# Altium schematic sheet [14] - POWER I.SchDoc("[14] - POWER I")
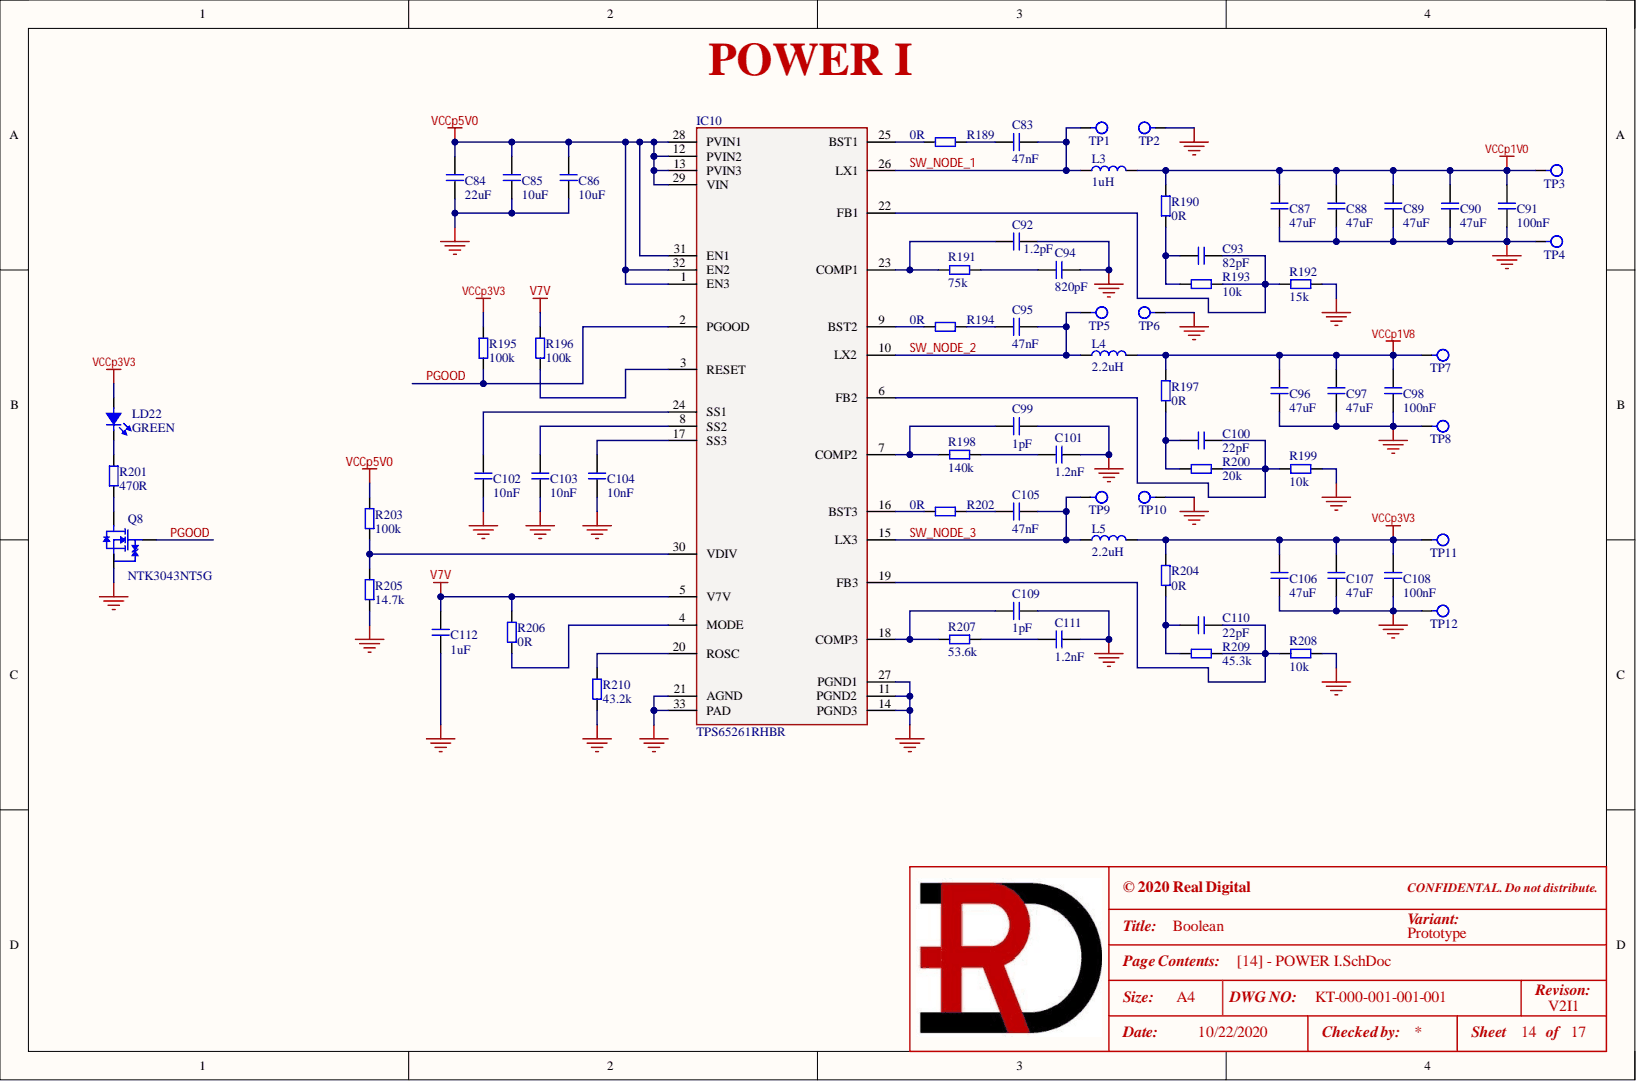
[diagram p0: [14] - POWER I.SchDoc]

## Parts
C83  47nF  [C0402]
C84  22uF  [C1210]
C85  10uF  [C1206]
C86  10uF  [C1206]
C87  47uF  [C1210]
C88  47uF  [C1210]
C89  47uF  [C1210]
C90  47uF  [C1210]
C91  100nF  [C0402]
C92  1.2pF  [C0402]
C93  82pF  [C0402]
C94  820pF  [C0402]
C95  47nF  [C0402]
C96  47uF  [C1210]
C97  47uF  [C1210]
C98  100nF  [C0402]
C99  1pF  [C0402]
C100  22pF  [C0402]
C101  1.2nF  [C0402]
C102  10nF  [C0402]
C103  10nF  [C0402]
C104  10nF  [C0402]
C105  47nF  [C0402]
C106  47uF  [C1210]
C107  47uF  [C1210]
C108  100nF  [C0402]
C109  1pF  [C0402]
C110  22pF  [C0402]
C111  1.2nF  [C0402]
C112  1uF  [C0603]
IC10  TPS65261RHBR  [VQFN-32_TI_RHB0032E]
L3  1uH  [BMRx00060630]
L4  2.2uH  [BMRx00060630]
L5  2.2uH  [BMRx00060630]
LD22  GREEN  [LD0603_Green]
Q8  NTK3043NT5G  [SOT723_ONSEMI]
R189  0R  [R0402]
R190  0R  [R0402]
R191  75k  [R0402]
R192  15k  [R0402]
R193  10k  [R0402]
R194  0R  [R0402]
R195  100k  [R0402]
R196  100k  [R0402]
R197  0R  [R0402]
R198  140k  [R0402]
R199  10k  [R0402]
R200  20k  [R0402]
R201  470R  [R0402]
R202  0R  [R0402]
R203  100k  [R0402]
R204  0R  [R0402]
R205  14.7k  [R0402]
R206  0R  [R0402]
R207  53.6k  [R0402]
R208  10k  [R0402]
R209  45.3k  [R0402]
R210  43.2k  [R0402]
TP1  1mm  [TP_1MM]  (value not drawn)  (no BOM)
TP2  1mm  [TP_1MM]  (value not drawn)  (no BOM)
TP3  1.5mm  [TP_1.5MM]  (value not drawn)  (no BOM)
TP4  1.5mm  [TP_1.5MM]  (value not drawn)  (no BOM)
TP5  1mm  [TP_1MM]  (value not drawn)  (no BOM)
TP6  1mm  [TP_1MM]  (value not drawn)  (no BOM)
TP7  1.5mm  [TP_1.5MM]  (value not drawn)  (no BOM)
TP8  1.5mm  [TP_1.5MM]  (value not drawn)  (no BOM)
TP9  1mm  [TP_1MM]  (value not drawn)  (no BOM)
TP10  1mm  [TP_1MM]  (value not drawn)  (no BOM)
TP11  1.5mm  [TP_1.5MM]  (value not drawn)  (no BOM)
TP12  1.5mm  [TP_1.5MM]  (value not drawn)  (no BOM)

## Nets
GND: C84.1 C85.1 C86.1 C87.1 C88.1 C89.1 C90.1 C91.1 C92.2 C94.2 C96.1 C97.1 C98.1 C99.2 C101.2 C102.1 C103.1 C104.1 C106.1 C107.1 C108.1 C109.2 C111.2 C112.1 IC10.11(PGND2) IC10.14(PGND3) IC10.21(AGND) IC10.27(PGND1) IC10.33(PAD) Q8.2 R192.1 R199.1 R205.1 R208.1 R210.1 TP2.1 TP4.1 TP6.1 TP8.1 TP10.1 TP12.1
V7V: C112.2 IC10.5(V7V) R196.2 R206.2
VCCp1V0: C87.2 C88.2 C89.2 C90.2 C91.2 L3.2 R190.2 TP3.1
VCCp1V8: C96.2 C97.2 C98.2 L4.2 R197.1 TP7.1
VCCp3V3: C106.2 C107.2 C108.2 L5.2 LD22.A R195.2 R204.1 TP11.1
VCCp5V0: C84.2 C85.2 C86.2 IC10.1(EN3) IC10.12(PVIN2) IC10.13(PVIN3) IC10.28(PVIN1) IC10.29(VIN) IC10.31(EN1) IC10.32(EN2) R203.2
PGOOD: IC10.2(PGOOD) Q8.1 R195.1
SW_NODE_1: C83.2 IC10.26(LX1) L3.1 TP1.1
SW_NODE_2: C95.2 IC10.10(LX2) L4.1 TP5.1
SW_NODE_3: C105.2 IC10.15(LX3) L5.1 TP9.1
NetC83_1: C83.1 R189.1
NetC92_1: C92.1 IC10.23(COMP1) R191.1
NetC93_1: C93.1 IC10.22(FB1) R192.2 R193.1
NetC93_2: C93.2 R190.1 R193.2
NetC94_1: C94.1 R191.2
NetC95_1: C95.1 R194.1
NetC99_1: C99.1 IC10.7(COMP2) R198.1
NetC100_1: C100.1 IC10.6(FB2) R199.2 R200.1
NetC100_2: C100.2 R197.2 R200.2
NetC101_1: C101.1 R198.2
NetC102_2: C102.2 IC10.24(SS1)
NetC103_2: C103.2 IC10.8(SS2)
NetC104_2: C104.2 IC10.17(SS3)
NetC105_1: C105.1 R202.1
NetC109_1: C109.1 IC10.18(COMP3) R207.1
NetC110_1: C110.1 IC10.19(FB3) R208.2 R209.1
NetC110_2: C110.2 R204.2 R209.2
NetC111_1: C111.1 R207.2
NetIC10_3: IC10.3(RESET) R196.1
NetIC10_4: IC10.4(MODE) R206.1
NetIC10_9: IC10.9(BST2) R194.2
NetIC10_16: IC10.16(BST3) R202.2
NetIC10_20: IC10.20(ROSC) R210.2
NetIC10_25: IC10.25(BST1) R189.2
NetIC10_30: IC10.30(VDIV) R203.1 R205.2
NetLD22_K: LD22.K R201.2
NetQ8_3: Q8.3 R201.1

## Library data of the parts
C83 C95 C105 = GRM155R71E473KA88J: CAP CER 0.047uF 25V X7R 0402; Manufacturer 1: Murata Electronics; .Checked_PCB: AC; .Creator: RV; C P/N: 111-0286; LoadStatus: Load; Tolerance: 10%; Category: Capacitors; Component Kind: Standard; Library Name: KT-RealDigital.IntLib; Manufacturer 1 Part Number: GRM155R71E473KA88J; Temperature Coefficient: X7R; Voltage - Rated: 25V; .Checked_SCH: AC
C84 = GCM32ER71C226ME19K: CAP CER 22uF 16V X7R 1210; Manufacturer 1: Murata Electronics; .Checked_PCB: AC; .Creator: RV; C P/N: RD-111-0010; LoadStatus: Load; Tolerance: ±20%; Category: Capacitors; Component Kind: Standard; Library Name: KT-RealDigital.IntLib; Manufacturer 1 Part Number: GCM32ER71C226ME19K; Temperature Coefficient: X7R; Voltage - Rated: 16V; .Checked_SCH: AC
C85 C86 = GCM31CR71C106KA64K: CAP CER 10uF 16V X7R 1206; Manufacturer 1: Murata Electronics; .Checked_PCB: AC; .Creator: RV; C P/N: RD-111-0011; LoadStatus: Load; Tolerance: 10%; Category: Capacitors; Component Kind: Standard; Library Name: KT-RealDigital.IntLib; Manufacturer 1 Part Number: GCM31CR71C106KA64K; Temperature Coefficient: X7R; Voltage - Rated: 16V; .Checked_SCH: AC
C87-C90 C96 C97 C106 C107 = GRM32EC81C476KE15K: CAP CER 47UF 16V X6S 1210; Manufacturer 1: Murata Electronics; Manufacturer 2 Part Number: GRM32EC81C476KE15L; .Checked_PCB: AC; .Creator: RV; LoadStatus: Load; Tolerance: 10%; Category: Capacitors; Component Kind: Standard; Library Name: KT-RealDigital.IntLib; Manufacturer 1 Part Number: GRM32EC81C476KE15K; Manufacturer 2: Murata Electronics; Temperature Coefficient: X6S; Voltage - Rated: 16V; .Checked_SCH: AC
C91 C98 C108 = GRM155R71E104KE14J: CAP CER 0.1uF 25V X7R 0402; Manufacturer 1: Murata Electronics; .Checked_PCB: AC; .Creator: RV; C P/N: RD-111-0006; LoadStatus: Load; Tolerance: 10%; Category: Capacitors; Component Kind: Standard; Library Name: KT-RealDigital.IntLib; Manufacturer 1 Part Number: GRM155R71E104KE14J; Temperature Coefficient: X7R; Voltage - Rated: 25V; .Checked_SCH: AC
C92 = GJM1555C1H1R2CB01D: CAP CER 1.2pF 50V C0G/NP0 0402; Manufacturer 1: Murata Electronics; .Checked_PCB: AC; .Creator: RV; C P/N: RD-111-0012; LoadStatus: Load; Temperature Coefficient: C0G, NP0; Tolerance: 0.25pF; Category: Capacitors; Component Kind: Standard; Library Name: KT-RealDigital.IntLib; Manufacturer 1 Part Number: GJM1555C1H1R2CB01D; Voltage - Rated: 50V; .Checked_SCH: AC
C93 = GCM1555C1H820FA16D: CAP CER 82pF 50V C0G/NP0 0402; Manufacturer 1: Murata Electronics; .Checked_PCB: AC; .Creator: RV; C P/N: 111-0289; LoadStatus: Load; Temperature Coefficient: C0G, NP0; Tolerance: 1%; Category: Capacitors; Component Kind: Standard; Library Name: KT-RealDigital.IntLib; Manufacturer 1 Part Number: GCM1555C1H820FA16D; Voltage - Rated: 50V; .Checked_SCH: AC
C94 = GRM1555C1H821JA01J: CAP CER 820pF 50V C0G/NP0 0402; Manufacturer 1: Murata Electronics; .Checked_PCB: AC; .Creator: RV; C P/N: RD-111-0013; LoadStatus: Load; Temperature Coefficient: C0G, NP0; Tolerance: 5%; Category: Capacitors; Component Kind: Standard; Library Name: KT-RealDigital.IntLib; Manufacturer 1 Part Number: GRM1555C1H821JA01J; Voltage - Rated: 50V; .Checked_SCH: AC
C99 C109 = GJM1555C1H1R0CB01D: CAP CER 1pF 50V C0G/NP0 0402; Manufacturer 1: Murata Electronics; .Checked_PCB: AC; .Creator: RV; C P/N: RD-111-0014; LoadStatus: Load; Temperature Coefficient: C0G, NP0; Tolerance: 0.25pF; Category: Capacitors; Component Kind: Standard; Library Name: KT-RealDigital.IntLib; Manufacturer 1 Part Number: GJM1555C1H1R0CB01D; Voltage - Rated: 50V; .Checked_SCH: AC
C100 C110 = GCM1555C1H220FA16D: CAP CER 22pF 50V C0G/NP0 0402; Manufacturer 1: Murata Electronics; .Checked_PCB: AC; .Creator: RV; C P/N: RD-111-0015; LoadStatus: Load; Temperature Coefficient: C0G, NP0; Tolerance: 1%; Category: Capacitors; Component Kind: Standard; Library Name: KT-RealDigital.IntLib; Manufacturer 1 Part Number: GCM1555C1H220FA16D; Voltage - Rated: 50V; .Checked_SCH: AC
C101 C111 = GCM155R71H122KA37D: CAP CER 1200pF 50V X7R 0402; Manufacturer 1: Murata Electronics; .Checked_PCB: AC; .Creator: RV; C P/N: RD-111-0002; LoadStatus: Load; Tolerance: 10%; Category: Capacitors; Component Kind: Standard; Library Name: KT-RealDigital.IntLib; Manufacturer 1 Part Number: GCM155R71H122KA37D; Temperature Coefficient: X7R; Voltage - Rated: 50V; .Checked_SCH: AC
C102-C104 = GRM155R71H103KA88J: CAP CER 10000pF 50V X7R 0402; Manufacturer 1: Murata Electronics; .Checked_PCB: AC; .Creator: RV; C P/N: RD-111-0003; LoadStatus: Load; Tolerance: 10%; Category: Capacitors; Component Kind: Standard; Library Name: KT-RealDigital.IntLib; Manufacturer 1 Part Number: GRM155R71H103KA88J; Temperature Coefficient: X7R; Voltage - Rated: 50V; .Checked_SCH: AC
C112 = GCJ188R71E105KA01D: CAP CER 1uF 25V X7R 0603; Manufacturer 1: Murata Electronics; .Checked_PCB: AC; .Creator: RV; C P/N: 111-0602; LoadStatus: Load; Tolerance: 10%; Category: Capacitors; Component Kind: Standard; Library Name: KT-RealDigital.IntLib; Manufacturer 1 Part Number: GCJ188R71E105KA01D; Temperature Coefficient: X7R; Voltage - Rated: 25V; .Checked_SCH: AC
IC10 = TPS65261RHBR: IC REG BCK ADJ 3A/2A TRPL 32VQFN; Manufacturer 1: Texas Instruments; .Checked_PCB: AC; .Creator: RV; C P/N: RD-126-0005; LoadStatus: Load; Category: IC; Component Kind: Standard; Library Name: KT-RealDigital.IntLib; Manufacturer 1 Part Number: TPS65261RHBR; .Checked_SCH: AC
L3 = BMRA000606301R0MA1: INDUCTOR, PWR; Manufacturer 1: Chilisin Electronics; .Checked_PCB: AC; .Creator: RV; C P/N: RD-131-0002; LoadStatus: Load; Category: Inductors; Component Kind: Standard; Library Name: KT-RealDigital.IntLib; Manufacturer 1 Part Number: BMRA000606301R0MA1; .Checked_SCH: AC
L4 L5 = BMRA000606302R2MA1: INDUCTOR, PWR; Manufacturer 1: Chilisin Electronics; .Checked_PCB: AC; .Creator: RV; C P/N: RD-131-0001; LoadStatus: Load; Category: Inductors; Component Kind: Standard; Library Name: KT-RealDigital.IntLib; Manufacturer 1 Part Number: BMRA000606302R2MA1; .Checked_SCH: AC
LD22 = LED_GREEN: LED GREEN 0603; Manufacturer 1: Everlight Electronics CO., LTD; Manufacturer 1 Part Number: 19-21SYGC/S530-E4/TR8; .Checked_PCB: AC; .Creator: RV; C P/N: 121-0006; LoadStatus: Load; Category: Led; Component Kind: Standard; Library Name: KT-RealDigital.IntLib; .Checked_SCH: AC
Q8 = NTK3043NT5G: MOSFET N-CH 20V 210MA SOT-723; Manufacturer 1: ON Semiconductor; .Creator: RV; C P/N: RD-121-0001; LoadStatus: Load; Category: Transistors; Component Kind: Standard; Library Name: KT-RealDigital.IntLib; Manufacturer 1 Part Number: NTK3043NT5G
R189 R190 R194 R197 R202 R204 R206 = RC0402FR-070RL: RES SMD 0 OHM JUMPER 1/16W 0402; Manufacturer 1: Yageo; .Checked_PCB: AC; .Creator: RV; C P/N: RD-101-0003; LoadStatus: Load; Category: Resistors; Component Kind: Standard; Library Name: KT-RealDigital.IntLib; Manufacturer 1 Part Number: RC0402FR-070RL; Tolerance: Jumper; .Checked_SCH: AC
R191 = RC0402FR-0775KL: RES SMD 75K OHM 1% 1/16W 0402; Manufacturer 1: Yageo; .Checked_PCB: AC; .Creator: RV; C P/N: 101-1083; LoadStatus: Load; Category: Resistors; Component Kind: Standard; Library Name: KT-RealDigital.IntLib; Manufacturer 1 Part Number: RC0402FR-0775KL; Tolerance: 1%; .Checked_SCH: AC
R192 = RT0402BRD0715KL: RES SMD 15K OHM 0.1% 1/16W 0402; Manufacturer 1: Yageo; .Checked_PCB: AC; .Creator: RV; C P/N: 101-0867; LoadStatus: Load; Category: Resistors; Component Kind: Standard; Library Name: KT-RealDigital.IntLib; Manufacturer 1 Part Number: RT0402BRD0715KL; Tolerance: 0.1%; .Checked_SCH: AC
R193 R199 R208 = RT0402BRB0710KL: RES SMD 10K OHM 0.1% 1/16W 0402; Manufacturer 1: Yageo; .Checked_PCB: AC; .Creator: RV; C P/N: 101-0862; LoadStatus: Load; Category: Resistors; Component Kind: Standard; Library Name: KT-RealDigital.IntLib; Manufacturer 1 Part Number: RT0402BRB0710KL; Tolerance: 0.1%; .Checked_SCH: AC
R195 R196 R203 = RC0402JR-07100KL: RES SMD 100K OHM 5% 1/16W 0402; Manufacturer 1: Yageo; .Checked_PCB: AC; .Creator: RV; C P/N: 101-0046; LoadStatus: Load; Category: Resistors; Component Kind: Standard; Library Name: KT-RealDigital.IntLib; Manufacturer 1 Part Number: RC0402JR-07100KL; Tolerance: 5%; .Checked_SCH: AC
R198 = RC0402FR-07140KL: RES SMD 140K OHM 1% 1/16W 0402; Manufacturer 1: Yageo; .Checked_PCB: AC; .Creator: RV; C P/N: 101-0466; LoadStatus: Load; Category: Resistors; Component Kind: Standard; Library Name: KT-RealDigital.IntLib; Manufacturer 1 Part Number: RC0402FR-07140KL; Tolerance: 1%; .Checked_SCH: AC
R200 = RT0402BRD0720KL: RES SMD 20K OHM 0.1% 1/16W 0402; Manufacturer 1: Yageo; .Checked_PCB: AC; .Creator: RV; C P/N: 101-1361; LoadStatus: Load; Category: Resistors; Component Kind: Standard; Library Name: KT-RealDigital.IntLib; Manufacturer 1 Part Number: RT0402BRD0720KL; Tolerance: 0.1%; .Checked_SCH: AC
R201 = RC0402JR-07470RL: RES SMD 470 OHM 5% 1/16W 0402; Manufacturer 1: Yageo; .Checked_PCB: AC; .Creator: RV; C P/N: 101-0052; LoadStatus: Load; Category: Resistors; Component Kind: Standard; Library Name: KT-RealDigital.IntLib; Manufacturer 1 Part Number: RC0402JR-07470RL; Tolerance: 5%; .Checked_SCH: AC
R205 = RC0402FR-0714K7L: RES SMD 14.7K OHM 1% 1/16W 0402; Manufacturer 1: Yageo; .Checked_PCB: AC; .Creator: RV; C P/N: 101-0493; LoadStatus: Load; Category: Resistors; Component Kind: Standard; Library Name: KT-RealDigital.IntLib; Manufacturer 1 Part Number: RC0402FR-0714K7L; Tolerance: 1%; .Checked_SCH: AC
R207 = RC0402FR-0753K6L: RES SMD 53.6K OHM 1% 1/16W 0402; Manufacturer 1: Yageo; .Checked_PCB: AC; .Creator: RV; C P/N: 101-0491; LoadStatus: Load; Category: Resistors; Component Kind: Standard; Library Name: KT-RealDigital.IntLib; Manufacturer 1 Part Number: RC0402FR-0753K6L; Tolerance: 1%; .Checked_SCH: AC
R209 = RT0402BRD0745K3L: RES SMD 45.3KOHM 0.1% 1/16W 0402; Manufacturer 1: Yageo; .Checked_PCB: AC; .Creator: RV; C P/N: RD-101-0004; LoadStatus: Load; Category: Resistors; Component Kind: Standard; Library Name: KT-RealDigital.IntLib; Manufacturer 1 Part Number: RT0402BRD0745K3L; Tolerance: 0.1%; .Checked_SCH: AC
R210 = RC0402FR-0743K2L: RES SMD 43.2K OHM 1% 1/16W 0402; Manufacturer 1: Yageo; .Checked_PCB: AC; .Creator: RV; C P/N: 101-0497; LoadStatus: Load; Category: Resistors; Component Kind: Standard; Library Name: KT-RealDigital.IntLib; Manufacturer 1 Part Number: RC0402FR-0743K2L; Tolerance: 1%; .Checked_SCH: AC
TP1 TP2 TP5 TP6 TP9 TP10 = TEST_POINT_1mm: TEST POINT round 1mm; Manufacturer 1: NA; .Creator: RV; LoadStatus: Load; Category: Test Point; Library Name: KT-RealDigital.IntLib; Manufacturer 1 Part Number: NA; Manufacturer 2: NA; Manufacturer 2 Part Number: NA
TP3 TP4 TP7 TP8 TP11 TP12 = TEST_POINT_1.5mm: TEST POINT - Round 1.5mm; Manufacturer 1: NA; .Creator: RV; LoadStatus: Load; Category: Test Point; Library Name: KT-RealDigital.IntLib; Manufacturer 1 Part Number: NA; Manufacturer 2: NA; Manufacturer 2 Part Number: NA
